# Altium schematic sheet UART Schematic.SchDoc("UART Schematic")
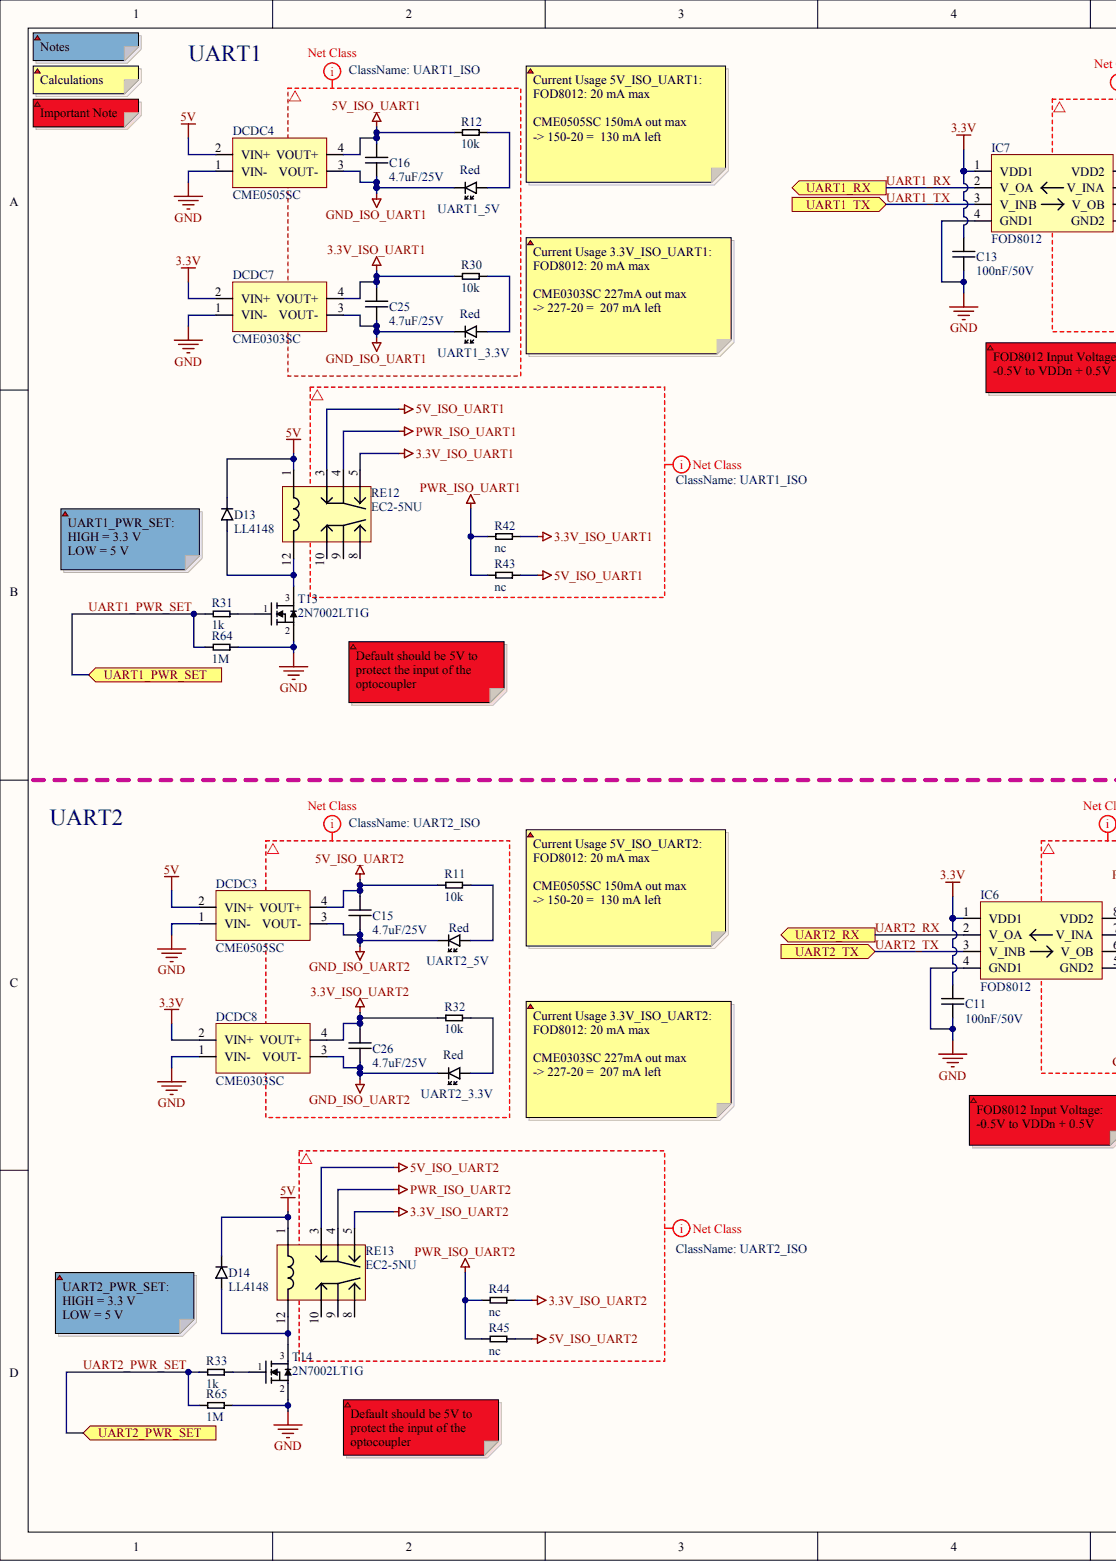
[diagram p0: UART Schematic.SchDoc, left]
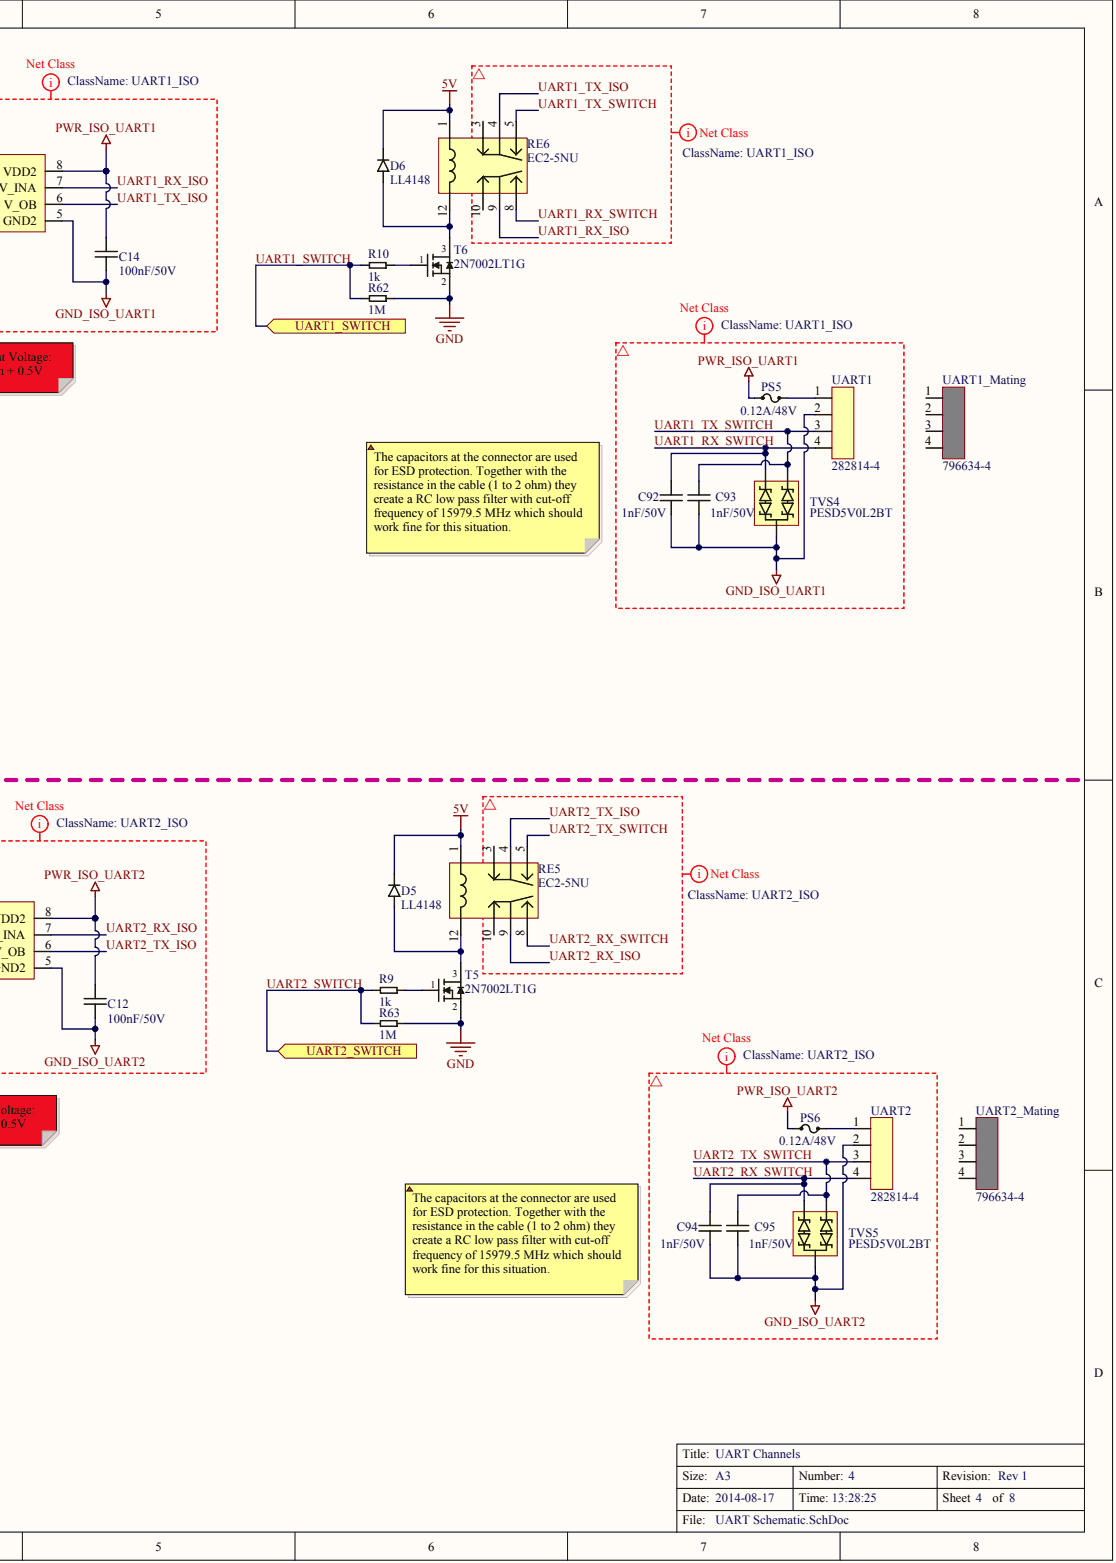
[diagram p1: UART Schematic.SchDoc, right]

## Parts
C11  value 100nF/50V  [0603]  (hidden comment: Cap_0603_100nF/50V)
C12  value 100nF/50V  [0603]  (hidden comment: Cap_0603_100nF/50V)
C13  value 100nF/50V  [0603]  (hidden comment: Cap_0603_100nF/50V)
C14  value 100nF/50V  [0603]  (hidden comment: Cap_0603_100nF/50V)
C15  value 4.7uF/25V  [0805]  (hidden comment: Cap_0805_4.7uF/25V)
C16  value 4.7uF/25V  [0805]  (hidden comment: Cap_0805_4.7uF/25V)
C25  value 4.7uF/25V  [0805]  (hidden comment: Cap_0805_4.7uF/25V)
C26  value 4.7uF/25V  [0805]  (hidden comment: Cap_0805_4.7uF/25V)
C92  value 1nF/50V  [0603]  (hidden comment: Cap_0603_1nF/50V)
C93  value 1nF/50V  [0603]  (hidden comment: Cap_0603_1nF/50V)
C94  value 1nF/50V  [0603]  (hidden comment: Cap_0603_1nF/50V)
C95  value 1nF/50V  [0603]  (hidden comment: Cap_0603_1nF/50V)
D5  LL4148
D6  LL4148
D13  LL4148
D14  LL4148
DCDC3  CME0505SC  [4-SIP]
DCDC4  CME0505SC  [4-SIP]
DCDC7  CME0303SC  [4-SIP]
DCDC8  CME0303SC  [4-SIP]
IC6  FOD8012
IC7  FOD8012
PS5  value 0.12A/48V  [SMD 1206]  (hidden comment: Polyswitch_1206_0.12Ahold_48V)
PS6  value 0.12A/48V  [SMD 1206]  (hidden comment: Polyswitch_1206_0.12Ahold_48V)
R9  value 1k  [0603]  (hidden comment: Res_0603_1k)
R10  value 1k  [0603]  (hidden comment: Res_0603_1k)
R11  value 10k  [0603]  (hidden comment: Res_0603_10k)
R12  value 10k  [0603]  (hidden comment: Res_0603_10k)
R30  value 10k  [0603]  (hidden comment: Res_0603_10k)
R31  value 1k  [0603]  (hidden comment: Res_0603_1k)
R32  value 10k  [0603]  (hidden comment: Res_0603_10k)
R33  value 1k  [0603]  (hidden comment: Res_0603_1k)
R42  value nc  [0603]  (hidden comment: Res_0603)
R43  value nc  [0603]  (hidden comment: Res_0603)
R44  value nc  [0603]  (hidden comment: Res_0603)
R45  value nc  [0603]  (hidden comment: Res_0603)
R62  value 1M  [0603]  (hidden comment: Res_0603_1M)
R63  value 1M  [0603]  (hidden comment: Res_0603_1M)
R64  value 1M  [0603]  (hidden comment: Res_0603_1M)
R65  value 1M  [0603]  (hidden comment: Res_0603_1M)
RE5  value EC2-5NU  Part No.: EC2-5NU  (hidden comment: Relay EC2-5NU)
RE6  value EC2-5NU  Part No.: EC2-5NU  (hidden comment: Relay EC2-5NU)
RE12  value EC2-5NU  Part No.: EC2-5NU  (hidden comment: Relay EC2-5NU)
RE13  value EC2-5NU  Part No.: EC2-5NU  (hidden comment: Relay EC2-5NU)
T5  2N7002LT1G  [SOT-23]
T6  2N7002LT1G  [SOT-23]
T13  2N7002LT1G  [SOT-23]
T14  2N7002LT1G  [SOT-23]
TVS4  PESD5V0L2BT
TVS5  PESD5V0L2BT
UART1  value 282814-4  Part No.: 282814-4  (hidden comment: Terminal Block 1x4 5.08mm)
UART1_3.3V  value Red  (hidden comment: LED_0603_Red)
UART1_5V  value Red  (hidden comment: LED_0603_Red)
UART1_Mating  value 796634-4  Part No.: 796634-4  (hidden comment: Terminal Block 1x4 5.08mm Mating Connector)
UART2  value 282814-4  Part No.: 282814-4  (hidden comment: Terminal Block 1x4 5.08mm)
UART2_3.3V  value Red  (hidden comment: LED_0603_Red)
UART2_5V  value Red  (hidden comment: LED_0603_Red)
UART2_Mating  value 796634-4  Part No.: 796634-4  (hidden comment: Terminal Block 1x4 5.08mm Mating Connector)

## Ports
UART1_PWR_SET, UART1_RX, UART1_SWITCH, UART1_TX, UART2_PWR_SET, UART2_RX, UART2_SWITCH, UART2_TX

## Nets
3.3V: C11.2 C13.2 DCDC7.2(VIN+) DCDC8.2(VIN+) IC6.1(VDD1) IC7.1(VDD1)
3.3V_ISO_UART1: C25.2 DCDC7.4(VOUT+) R30.2 R42.2 RE12.5
3.3V_ISO_UART2: C26.2 DCDC8.4(VOUT+) R32.2 R44.2 RE13.5
5V: D5.1 D6.1 D13.1 D14.1 DCDC3.2(VIN+) DCDC4.2(VIN+) RE5.1 RE6.1 RE12.1 RE13.1
5V_ISO_UART1: C16.2 DCDC4.4(VOUT+) R12.2 R43.2 RE12.3
5V_ISO_UART2: C15.2 DCDC3.4(VOUT+) R11.2 R45.2 RE13.3
GND: C11.1 C13.1 DCDC3.1(VIN-) DCDC4.1(VIN-) DCDC7.1(VIN-) DCDC8.1(VIN-) IC6.4(GND1) IC7.4(GND1) R62.2 R63.2 R64.2 R65.2 T5.2 T6.2 T13.2 T14.2
GND_ISO_UART1: C14.1 C16.1 C25.1 C92.1 C93.1 DCDC4.3(VOUT-) DCDC7.3(VOUT-) IC7.5(GND2) TVS4.3 UART1.2 UART1_3.3V.CATHODE UART1_5V.CATHODE
GND_ISO_UART2: C12.1 C15.1 C26.1 C94.1 C95.1 DCDC3.3(VOUT-) DCDC8.3(VOUT-) IC6.5(GND2) TVS5.3 UART2.2 UART2_3.3V.CATHODE UART2_5V.CATHODE
PWR_ISO_UART1: C14.2 IC7.8(VDD2) PS5.1 R42.1 R43.1 RE12.4
PWR_ISO_UART2: C12.2 IC6.8(VDD2) PS6.1 R44.1 R45.1 RE13.4
UART1_PWR_SET: R31.1 R64.1 ; port UART1_PWR_SET
UART1_RX: IC7.2(V_OA) ; port UART1_RX
UART1_RX_ISO: IC7.7(V_INA) RE6.9
UART1_RX_SWITCH: C92.2 RE6.8 TVS4.2 UART1.4
UART1_SWITCH: R10.1 R62.1 ; port UART1_SWITCH
UART1_TX: IC7.3(V_INB) ; port UART1_TX
UART1_TX_ISO: IC7.6(V_OB) RE6.4
UART1_TX_SWITCH: C93.2 RE6.5 TVS4.1 UART1.3
UART2_PWR_SET: R33.1 R65.1 ; port UART2_PWR_SET
UART2_RX: IC6.2(V_OA) ; port UART2_RX
UART2_RX_ISO: IC6.7(V_INA) RE5.9
UART2_RX_SWITCH: C94.2 RE5.8 TVS5.2 UART2.4
UART2_SWITCH: R9.1 R63.1 ; port UART2_SWITCH
UART2_TX: IC6.3(V_INB) ; port UART2_TX
UART2_TX_ISO: IC6.6(V_OB) RE5.4
UART2_TX_SWITCH: C95.2 RE5.5 TVS5.1 UART2.3
NetD5_2: D5.2 RE5.12 T5.3
NetD6_2: D6.2 RE6.12 T6.3
NetD13_2: D13.2 RE12.12 T13.3
NetD14_2: D14.2 RE13.12 T14.3
NetPS5_2: PS5.2 UART1.1
NetPS6_2: PS6.2 UART2.1
NetR9_2: R9.2 T5.1
NetR10_2: R10.2 T6.1
NetR11_1: R11.1 UART2_5V.ANODE
NetR12_1: R12.1 UART1_5V.ANODE
NetR30_1: R30.1 UART1_3.3V.ANODE
NetR31_2: R31.2 T13.1
NetR32_1: R32.1 UART2_3.3V.ANODE
NetR33_2: R33.2 T14.1
N00402:
N00403:
N00404:
N00405:
N00406:
N00407:
N00408:
N00409:
N00410:
N00411:

Not connected: RE5 3 10; RE6 3 10; RE12 8 9 10; RE13 8 9 10; UART1_Mating 1 2 3 4; UART2_Mating 1 2 3 4

## Library data of the parts
D5 D6 D13 D14 = (no symbol name): Manufacturer: Fairchild Semiconductor; Mouser: 512-LL4148; DigiKey: LL4148FSCT-ND; Value: LL4148; Price SEK (No VAT): 1; Part No.: LL4148
DCDC3 DCDC4 = (no symbol name): Manufacturer: Murata; DigiKey: 811-2894-5-ND; Part No.: CME0505SC; Mouser: 580-CME0505SC; Datasheet: http://www.murata-ps.com/data/power/ncl/kdc_cme.pdf; Price SEK (No VAT): 28
DCDC7 DCDC8 = (no symbol name): Part No.: CME0303S3C; Manufacturer: Murata; DigiKey: 811-2889-5-ND; Mouser: 580-CME0303S3C; Datasheet: http://www.murata-ps.com/data/power/ncl/kdc_cme.pdf; Price SEK (No VAT): 28
IC6 IC7 = (no symbol name): Manufacturer: Fairchild Semiconductor; Note: Should be able to replace ADUM1201 as pin-compatible; DigiKey: FOD8012-ND; Part No.: FOD8012; Price SEK (No VAT): 31; Datasheet: http://www.fairchildsemi.com/ds/FO/FOD8012.pdf; Mouser: 512-FOD8012; Value: FOD8012
PS5 PS6 = (no symbol name): Part No.: NANOSMDC012F-2; Manufacturer: TE Connectivity; RS: 782-6455; Mouser: 650-NANOSMDC012F-2; Price SEK (No VAT): 2; DigiKey: NANOSMDC012FCT-ND; Farnell: 1345937
R10 = (no symbol name): Value: 1k; Price (No VAT): 0,1
RE5 RE6 RE12 RE13 = (no symbol name): Manufacturer: Kemet; Mouser: 80-EC2-5NU; DigiKey: 399-11052-5-ND; Price SEK (No VAT): 12; Datasheet: http://www.kemet.com/Lists/ProductCatalog/Attachments/527/KEM_R7002_EC2_EE2.pdf
T5 T6 T13 T14 = (no symbol name): Manufacturer: ON Semiconductor; Mouser: 863-2N7002LT1G; Part No.: 2N7002LT1G; DigiKey: 2N7002LT1GOSCT-ND; Price SEK (No VAT): 2; Value: 2N7002LT1G
TVS4 TVS5 = (no symbol name): Manufacturer: NXP; Part No.: PESD5V0L2BT,215; Mouser: 771-PESD5V0L2BT-T/R; DigiKey: 568-4050-1-ND; Price SEK (No VAT): 4; Value: PESD5V0L2BT
UART1 UART2 = (no symbol name): Manufacturer: TE Connectivity; Mating Connector: 796634-4; Mouser: 571-282814-4; RS: *; DigiKey: A98067-ND; Elfa: *; Price SEK (No VAT): 8
UART1_Mating UART2_Mating = (no symbol name): Manufacturer: TE Connectivity; Mouser: 571-7966344; RS: *; DigiKey: A98225-ND; Elfa: *; Price SEK (No VAT): 18
C11-C16 C25 C26 C92-C95 R9 R11 R12 R30-R33 R42-R45 R62-R65 UART1_3.3V UART1_5V UART2_3.3V UART2_5V = (no symbol name)
  R9 R11 R12 R30-R33 R42-R45 R62-R65: Price (No VAT): 0,1
